# Altium schematic sheet 78M05_RT9193.SchDoc
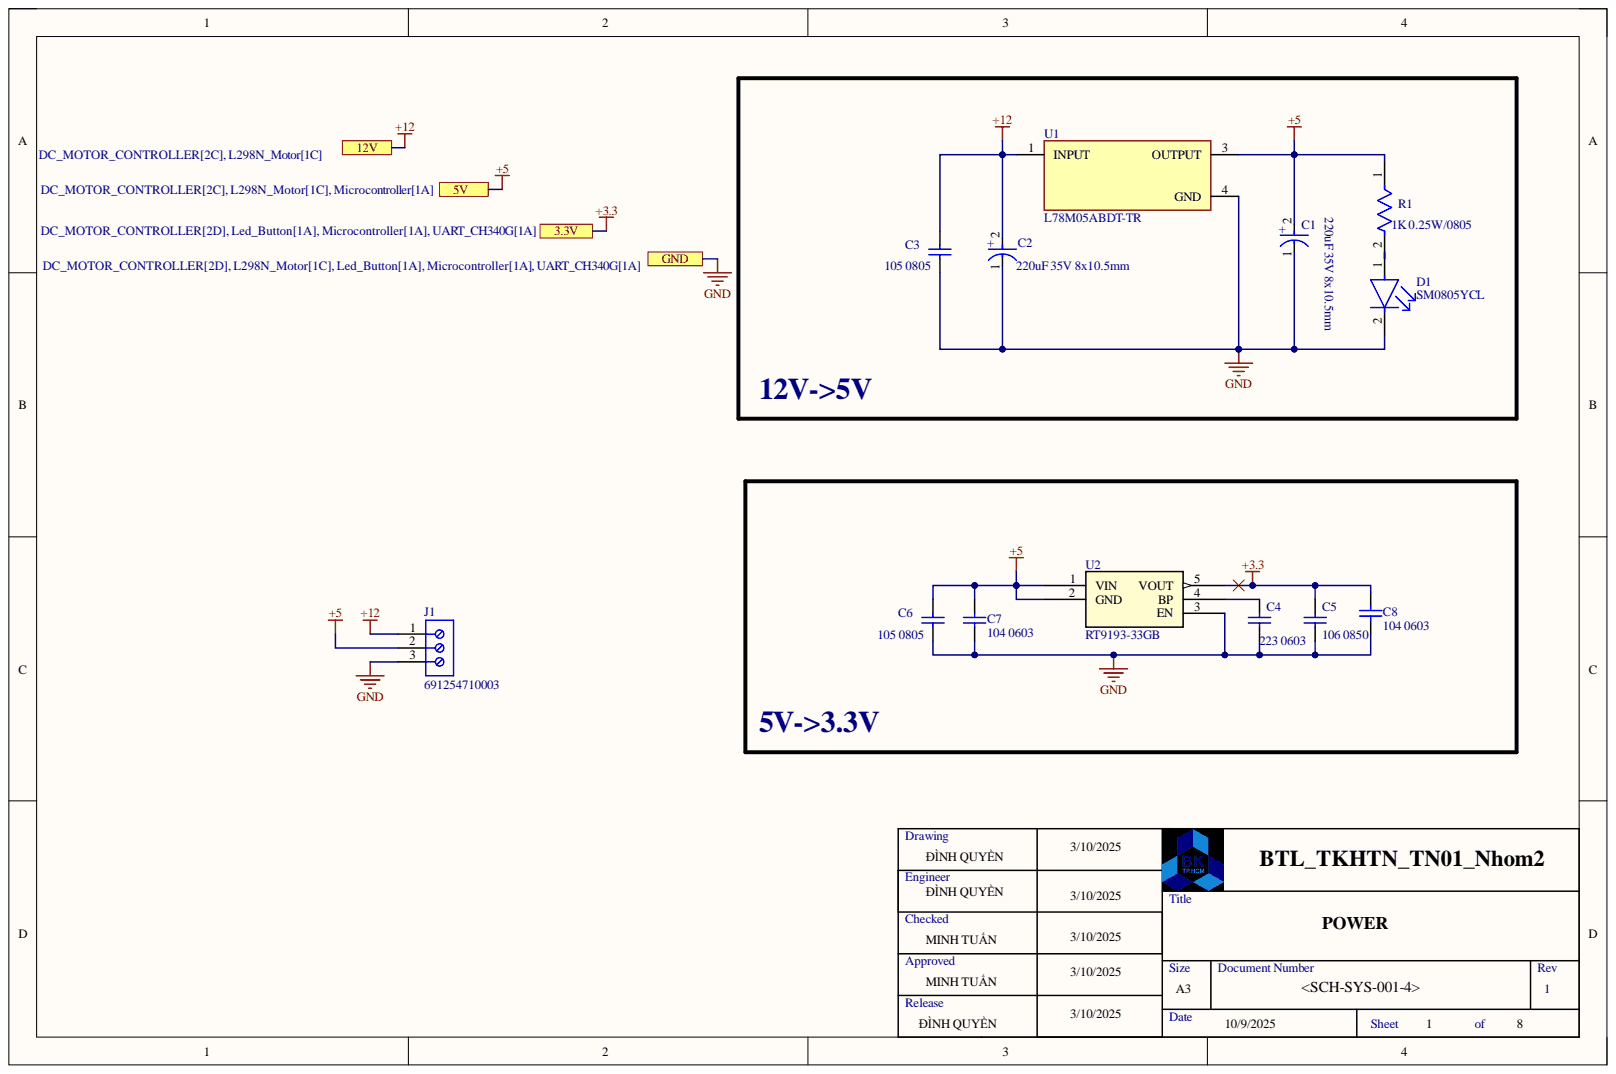
[diagram p0: 78M05_RT9193.SchDoc]

## Parts
C1  220uF 35V 8x10.5mm  Capacitance: 220uF  Voltage: 35V  [FP-FPF-MFG]
C2  220uF 35V 8x10.5mm  Capacitance: 220uF  [FP-FPF-MFG]
C3  105 0805  Case-EIA: 0805  [CAPC0805(2012)145_M]
C4  223 0603  [CAPC1608X92X38ML15T08]
C5  106 0850  [CAPC0805(2012)145_M]
C6  105 0805  Case-EIA: 0805  [CAPC0805(2012)145_M]
C7  104 0603  [CAPC1608X92X38ML15T08]
C8  104 0603  [CAPC1608X92X38ML15T08]
D1  SM0805YCL  [FP-SM0805YCL-MFG]
J1  691254710003  [691254710003]
R1  1K 0.25W/0805  [FP-AF0805-IPC_C]
U1  L78M05ABDT-TR  [FP-L78M05ABDT-TR-MFG]
U2  RT9193-33GB  [SOT-23-5_RIT]

## Ports
3.3V, 5V, 12V, GND

## Nets
+3.3: C5.2 C8.2 U2.5(VOUT) ; port 3.3V
+5: C1.2 C6.2 C7.2 J1.2 R1.1 U1.3 U2.1(VIN) U2.2(GND) ; port 5V
+12: C2.2 C3.2 J1.1 U1.1 ; port 12V
GND: C1.1 C2.1 C3.1 C4.1 C5.1 C6.1 C7.1 C8.1 D1.2 J1.3 U1.4 U2.3(EN) ; port GND
NetC4_2: C4.2 U2.4(BP)
NetD1_1: D1.1 R1.2

## Library data of the parts
C1 C2 = CMP-2000-06215-2: CAP ALUM 220UF 20% 35V SMD; ESR (Equivalent Series Resistance): 80mR; Leakage Current: 77uA; Life (Hours): 2000Hour; Material: Aluminium; Polarity: Polar; Ripple Current: 850mA; Case/Package: Radial; Component Kind: Standard; Construction: Cylindrical; Contact Plating: Tin; Diameter: 8mm; Height: 10.2mm; Height - Seated (Max): 0.402inch; Lead Free: Lead Free; Lead Pitch: 3.1mm; Length: 10.5mm; Library Name: Altium Content Vault; Max Operating Temperature: 105°C; Min Operating Temperature: -55°C; Mount: Surface Mount; Number of Pins: 2; Package Quantity: 500; Packaging: Tape and Reel; Radiation Hardening: No; REACH SVHC: No SVHC; Ripple Current (AC): 850mA; RoHS Compliant: Yes; Series: FP; Termination: SMD/SMT; Tolerance: 20%; Voltage Rating: 35V; Voltage Rating (DC): 35V; Width: 8.3mm
  C2: Voltage: 35V
C3 C6 = CMP-1036-03968-1: CAP 15nF 100V ±10% 0805 (2012 Metric) Thickness 1.45mm SMD; Case-Metric: 2012; Tolerance: Â±10%; Component Kind: Standard; Library Name: Altium Content Vault; Max Thickness: 1.45 mm; Rated Voltage: 100 V; Technology: SMT; Value: 15nF
C4 C7 C8 = CMP-2006-01201-1: Manufacturer: Vitramon; Part Number: VJ0603Y103JXXAC; Component Kind: Standard; Library Name: Altium Content Vault
C5 = CMP-1036-03968-1: CAP 15nF 100V ±10% 0805 (2012 Metric) Thickness 1.45mm SMD; Case-EIA: 0805; Case-Metric: 2012; Tolerance: Â±10%; Component Kind: Standard; Library Name: Altium Content Vault; Max Thickness: 1.45 mm; Rated Voltage: 100 V; Technology: SMT; Value: 15nF
D1 = CMP-35283-000007-1: LED YELLOW CLEAR 0805 SMD; Dominant Wavelength: 592nm; Forward Current: 2mA; Forward Voltage: 1.9V; Lens Color: Colorless; Luminous Intensity: 11.5mcd; Viewing Angle: 130°; Case Code (Imperial): 0805; Case Code (Metric): 2012; Case/Package: 0805; Color: Yellow; Component Kind: Standard; Height: 0.8mm; Illumination Color: Yellow; Lead Free: Lead Free; Length: 2mm; Lens Transparency: Clear; Library Name: Altium Content Vault; Max Operating Temperature: 80°C; Min Operating Temperature: -30°C; Mount: Surface Mount; Package Quantity: 4000; Packaging: Tape and Reel; Test Current: 2mA; Width: 1.2mm
J1 = CMP-1502-02592-2: NexarPartId: 105950850; Component Kind: Standard; Library Name: Altium Content Vault
R1 = CMP-03412-045285-1: Anti-Sulfurated Chip Resistor Automotive Grade 75Ω 1% 0.125W ±100ppm/°C 0805; Max Power Dissipation: 125mW; Resistance: 75R; Case/Package: 0805; Component Kind: Standard; Features: Moisture Resistant; Height: 0.024inch; Length: 0.079inch; Library Name: Altium Content Vault; Max Operating Temperature: 155°C; Min Operating Temperature: -55°C; Number of Terminations: 2; Packaging: Tape and Reel; Resistor Type: Thick Film; RoHS Compliant: Yes; Series: AF; Temperature Coefficient: 100ppm/°C; Tolerance: 1%; Width: 0.049inch
U1 = CMP-0244-00177-2: IC REG LINEAR 5V 500MA DPAK; Accuracy: 2%; Dropout Voltage: 2V; Max Input Voltage: 35V; Min Input Voltage: 10V; Number of Outputs: 1; Output Type: Fixed; Polarity: Positive; Power Supply Rejection Ratio (PSRR): 62dB; Quiescent Current: 6mA; Tolerance: 4%; Case/Package: TO-252; Component Kind: Standard; Contact Plating: Tin; Height: 2.4mm; Lead Free: Lead Free; Length: 6.6mm; Library Name: Altium Content Vault; Max Operating Temperature: 125°C; Max Output Current: 500mA; Min Operating Temperature: -40°C; Min Output Voltage: 5V; Mount: Surface Mount; Nominal Output Voltage: 5V; Number of Pins: 3; Number of Regulators: 1; Output Current: 500mA; Output Voltage: 5V; Output Voltage Accuracy: 2%; Package Quantity: 1; Packaging: Tape and Reel; Radiation Hardening: No; REACH SVHC: No SVHC; Weight: 0.01lb; Width: 6.2mm
U2 = RT9193-33GB: No Description Available; Manufacturer_Name: Richtek Technology; Component Kind: Standard; Library Name: RT9193-33GB.IntLib; Manufacturer_Part_Number: RT9193-33GB; RefDes: RefDes; Type: Type; Copyright: Copyright (C) 2025 Ultra Librarian. All rights reserved.
